# Altium schematic sheet Nixie_MCU_ARM_v2.SchDoc(Nixie_MCU_ARM_v2)
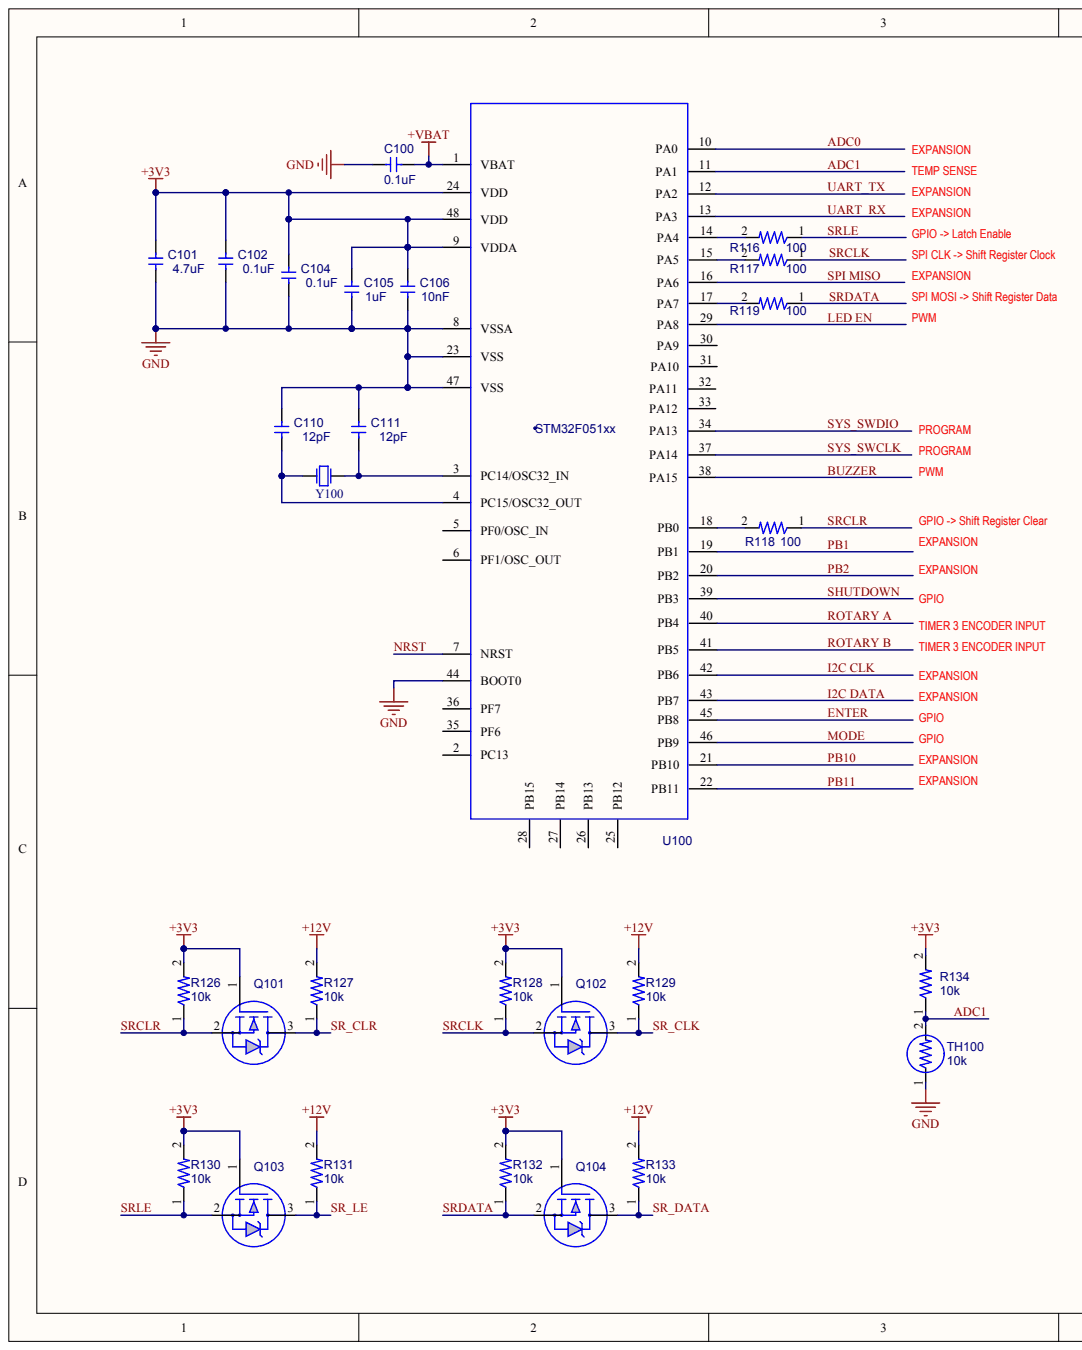
[diagram p0: Nixie_MCU_ARM_v2.SchDoc, left]
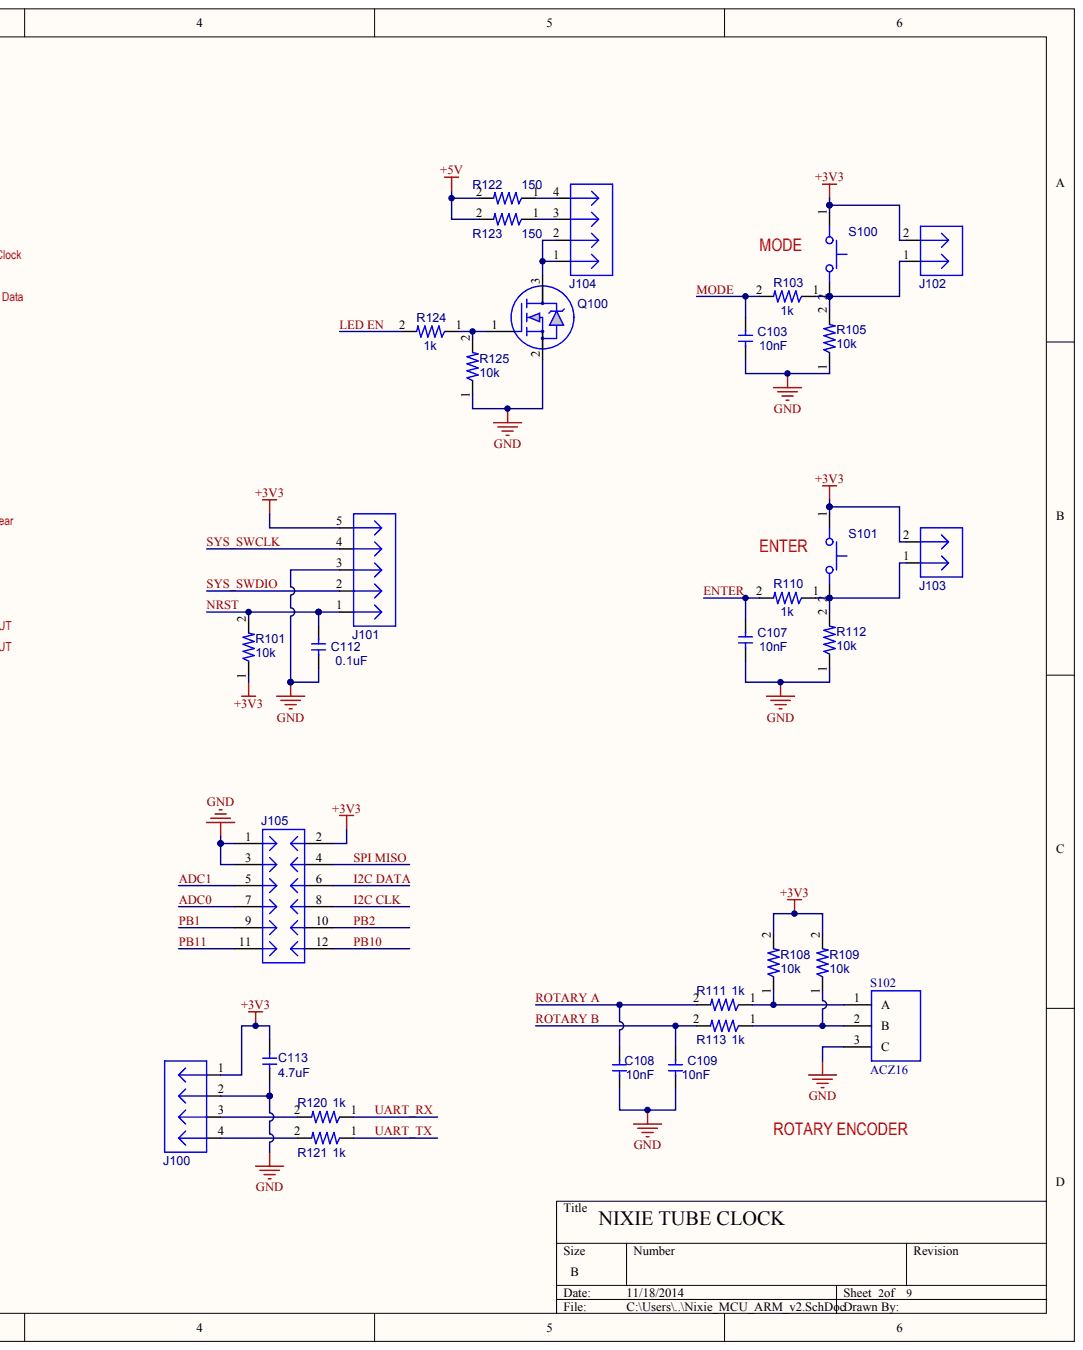
[diagram p1: Nixie_MCU_ARM_v2.SchDoc, right]

## Parts
C100  value 0.1uF  (hidden comment: CAP)
C101  value 4.7uF  (hidden comment: CAP)
C102  value 0.1uF  (hidden comment: CAP)
C103  value 10nF  (hidden comment: CAP)
C104  value 0.1uF  (hidden comment: CAP)
C105  value 1uF  (hidden comment: CAP)
C106  value 10nF  (hidden comment: CAP)
C107  value 10nF  (hidden comment: CAP)
C108  value 10nF  (hidden comment: CAP)
C109  value 10nF  (hidden comment: CAP)
C110  value 12pF  (hidden comment: CAP)
C111  value 12pF  (hidden comment: CAP)
C112  value 0.1uF  (hidden comment: CAP)
C113  value 4.7uF  (hidden comment: CAP)
J100  BERG1X4  (value not drawn)
J101  BERG1X5  (value not drawn)
J102  BERG1X2  (value not drawn)
J103  BERG1X2  (value not drawn)
J104  BERG1X4  (value not drawn)
J105  BERG2X6  (value not drawn)
Q100  2N7002  (value not drawn)
Q101  2N7002  (value not drawn)
Q102  2N7002  (value not drawn)
Q103  2N7002  (value not drawn)
Q104  2N7002  (value not drawn)
R101  value 10k  (hidden comment: RES)
R103  value 1k  (hidden comment: RES)
R105  value 10k  (hidden comment: RES)
R108  value 10k  (hidden comment: RES)
R109  value 10k  (hidden comment: RES)
R110  value 1k  (hidden comment: RES)
R111  value 1k  (hidden comment: RES)
R112  value 10k  (hidden comment: RES)
R113  value 1k  (hidden comment: RES)
R116  value 100  (hidden comment: RES)
R117  value 100  (hidden comment: RES)
R118  value 100  (hidden comment: RES)
R119  value 100  (hidden comment: RES)
R120  value 1k  (hidden comment: RES)
R121  value 1k  (hidden comment: RES)
R122  value 150  (hidden comment: RES)
R123  value 150  (hidden comment: RES)
R124  value 1k  (hidden comment: RES)
R125  value 10k  (hidden comment: RES)
R126  value 10k  (hidden comment: RES)
R127  value 10k  (hidden comment: RES)
R128  value 10k  (hidden comment: RES)
R129  value 10k  (hidden comment: RES)
R130  value 10k  (hidden comment: RES)
R131  value 10k  (hidden comment: RES)
R132  value 10k  (hidden comment: RES)
R133  value 10k  (hidden comment: RES)
R134  value 10k  (hidden comment: RES)
S100  TL6110CF500QP  (value not drawn)
S101  TL6110CF500QP  (value not drawn)
S102  ACZ16
TH100  value 10k  (hidden comment: THERM)
U100  STM32F051xx
Y100  KHz  (value not drawn)

## Nets
+3V3: C101.1 C102.1 C104.1 C105.1 C106.1 C113.2 J100.1 J101.5 J102.2 J103.2 J105.2 Q101.1 Q102.1 Q103.1 Q104.1 R101.1 R108.2 R109.2 R126.2 R128.2 R130.2 R132.2 R134.2 S100.1 S101.1 U100.9(VDDA) U100.24(VDD) U100.48(VDD)
+5V: R122.2 R123.2
+12V: R127.2 R129.2 R131.2 R133.2
+VBAT: C100.2 U100.1(VBAT)
GND: C100.1 C101.2 C102.2 C103.2 C104.2 C105.2 C106.2 C107.2 C108.2 C109.2 C110.1 C111.1 C112.2 C113.1 J100.2 J101.3 J105.1 J105.3 Q100.2 R105.1 R112.1 R125.1 S102.3 TH100.1 U100.8(VSSA) U100.23(VSS) U100.44(BOOT0) U100.47(VSS)
ADC0: J105.7 U100.10(PA0)
ADC1: J105.5 R134.1 TH100.2 U100.11(PA1)
BUZZER: U100.38(PA15)
ENTER: C107.1 R110.2 U100.45(PB8)
I2C CLK: J105.8 U100.42(PB6)
I2C DATA: J105.6 U100.43(PB7)
LED EN: R124.2 U100.29(PA8)
MODE: C103.1 R103.2 U100.46(PB9)
NRST: C112.1 J101.1 R101.2 U100.7(NRST)
PB1: J105.9 U100.19(PB1)
PB2: J105.10 U100.20(PB2)
PB10: J105.12 U100.21(PB10)
PB11: J105.11 U100.22(PB11)
ROTARY A: C108.1 R111.2 U100.40(PB4)
ROTARY B: C109.1 R113.2 U100.41(PB5)
SHUTDOWN: U100.39(PB3)
SPI MISO: J105.4 U100.16(PA6)
SR_CLK: Q102.3 R129.1
SR_CLR: Q101.3 R127.1
SR_DATA: Q104.3 R133.1
SR_LE: Q103.3 R131.1
SRCLK: Q102.2 R117.1 R128.1
SRCLR: Q101.2 R118.1 R126.1
SRDATA: Q104.2 R119.1 R132.1
SRLE: Q103.2 R116.1 R130.1
SYS_SWCLK: J101.4 U100.37(PA14)
SYS_SWDIO: J101.2 U100.34(PA13)
UART_RX: R120.1 U100.13(PA3)
UART_TX: R121.1 U100.12(PA2)
NetC110_2: C110.2 U100.4(PC15/OSC32_OUT) Y100.2
NetC111_2: C111.2 U100.3(PC14/OSC32_IN) Y100.1
NetJ100_3: J100.3 R120.2
NetJ100_4: J100.4 R121.2
NetJ102_1: J102.1 R103.1 R105.2 S100.2
NetJ103_1: J103.1 R110.1 R112.2 S101.2
NetJ104_1: J104.1 J104.2 Q100.3
NetJ104_3: J104.3 R123.1
NetJ104_4: J104.4 R122.1
NetQ100_1: Q100.1 R124.1 R125.2
NetR108_1: R108.1 R111.1 S102.1
NetR109_1: R109.1 R113.1 S102.2
NetR116_2: R116.2 U100.14(PA4)
NetR117_2: R117.2 U100.15(PA5)
NetR118_2: R118.2 U100.18(PB0)
NetR119_2: R119.2 U100.17(PA7)

Not connected: U100 2(PC13) 5(PF0/OSC_IN) 6(PF1/OSC_OUT) 25(PB12) 26(PB13) 27(PB14) 28(PB15) 30(PA9) 31(PA10) 32(PA11) 33(PA12) 35(PF6) 36(PF7)

## Library data of the parts
C100 C102 C104 C112 = (no symbol name): Manufacturer: Samsung; Manufacturer Part Number: CAP CER 0.1UF 50V 10% X7R 0603; Tolerance: 10%; Voltage: 50V; Revision: A; Component Kind: Standard; Cost: 0.0091; Supplier 1: Digi-key; Supplier Part Number 1: 1276-1936-1-ND; IPC Pattern: 0603
C101 C113 = (no symbol name): Manufacturer: Samsung; Manufacturer Part Number: CL21A475KAQNNNE; Tolerance: 10%; Voltage: 25V; Revision: A; Component Kind: Standard; Cost: 0.0506; Supplier 1: Digi-key; Supplier Part Number 1: 1276-1244-1-ND; IPC Pattern: 0805
C103 C106-C109 = (no symbol name): Manufacturer: Samsung; Manufacturer Part Number: CL10B103KB8NNNC; Tolerance: 10%; Voltage: 50V; Revision: A; Component Kind: Standard; Cost: 0.0083; Supplier 1: Digi-key; Supplier Part Number 1: 276-1009-1-ND; IPC Pattern: 0603
C105 = (no symbol name): Manufacturer: Samsung; Manufacturer Part Number: CL21B105KBFNNNE; Tolerance: 10%; Voltage: 50V; Revision: A; Component Kind: Standard; Cost: 0.071; Supplier 1: Digi-key; Supplier Part Number 1: 1276-1029-1-ND; IPC Pattern: 0805
C110 C111 = (no symbol name): Manufacturer: Samsung; Manufacturer Part Number: CL05C120JB5NNNC; Tolerance: 5%; Voltage: 50V; Revision: A; Component Kind: Standard; Cost: 0.0059; Supplier 1: Digi-key; Supplier Part Number 1: 1276-1178-1-ND; IPC Pattern: 0402
J100-J105 = (no symbol name): Manufacturer: {Manufacturer}; Manufacturer Part Number: {Manuf Part #}; Tolerance: {Tolerance}; Voltage: {Voltage}; Value: {Value}; Revision: A; Cost: {Cost}; Supplier 1: Supplier 1; Supplier Part Number 1: Supplier Part Number 1; Component Kind: Standard; IPC Pattern: {IPC Pattern}
Q100-Q104 = (no symbol name): Manufacturer: Fairchild Semiconductor; Manufacturer Part Number: BSS138; Voltage: 50V; Revision: A; Component Kind: Standard; Cost: 0.1408; Supplier 1: Digi-key; Supplier Part Number 1: BSS138CT-ND; Tolerance: -; Value: -; IPC Pattern: SOT23
R101 R105 R108 R109 R112 R125-R134 = (no symbol name): Manufacturer: Panasonic; Manufacturer Part Number: ERJ-3GEYJ103V; Tolerance: 5%; Voltage: -; Revision: A; Cost: 0.0096; Supplier 1: Digi-key; Supplier Part Number 1: P10KGCT-ND; Component Kind: Standard; IPC Pattern: 0603
R103 R110 R111 R113 R120 R121 R124 = (no symbol name): Manufacturer: Panasonic; Manufacturer Part Number: ERJ-3GEYJ102V; Tolerance: 5%; Voltage: -; Revision: A; Cost: 0.0096; Supplier 1: Digi-key; Supplier Part Number 1: P1.0KGCT-ND; Component Kind: Standard; IPC Pattern: 0603
R116-R119 = (no symbol name): Manufacturer: Panasonic; Manufacturer Part Number: ERJ-3GEYJ101V; Tolerance: 5%; Voltage: -; Revision: A; Cost: 0.0096; Supplier 1: Digi-key; Supplier Part Number 1: P100GCT-ND; Component Kind: Standard; IPC Pattern: 0603
R122 R123 = (no symbol name): Manufacturer: Panasonic; Manufacturer Part Number: ERJ-6ENF1500V; Tolerance: 1%; Voltage: -; Revision: A; Cost: 0.0288; Supplier 1: Digi-key; Supplier Part Number 1: P150CCT-ND; Component Kind: Standard; IPC Pattern: 0805
S100 S101 = (no symbol name): Manufacturer: Apem, Inc; Manufacturer Part Number: MJTP1129A; Tolerance: -; Voltage: -; Revision: A; Value: -; Cost: 0.84; Supplier 1: Digi-key; Supplier Part Number 1: 679-2408-ND; Component Kind: Standard; IPC Pattern: TH RA
S102 = (no symbol name): Manufacturer: CUI, Inc; Manufacturer Part Number: ACZ16NBR1E-15FA1-12C; Cost: 2.28; IPC Pattern: TH RA; Supplier 1: Digi-key; Supplier Part Number 1: 102-1773-ND; Tolerance: -; Value: -; Voltage: -
TH100 = (no symbol name): Manufacturer: TDK Corporation; Manufacturer Part Number: NTCG163JF103FT1; Tolerance: 1%; Voltage: -; Revision: A; Cost: 0.338; Supplier 1: Digi-key; Supplier Part Number 1: 445-2554-1-ND; Component Kind: Standard; IPC Pattern: 0603
U100 = (no symbol name): Manufacturer: ST Microelectronics; Manufacturer Part Number: STM32F051C6T6; Value: -; IPC Pattern: 48-LQFP; Cost: 2.9; Supplier 1: Digi-key; Supplier Part Number 1: 497-12948-ND; Component Kind: Standard; Tolerance: -; Voltage: -
Y100 = (no symbol name): Manufacturer: ECS, Inc; Manufacturer Part Number: ECS-.327-12.5-17X-C-TR; Tolerance: 10ppm; Value: 12.5pF; Cost: 1.24; Component Kind: Standard; IPC Pattern: SMD; Supplier 1: Digi-key; Supplier Part Number 1: XC1912CT-ND; Voltage: -
